# Altium schematic sheet StudentForce_MCU.SchDoc
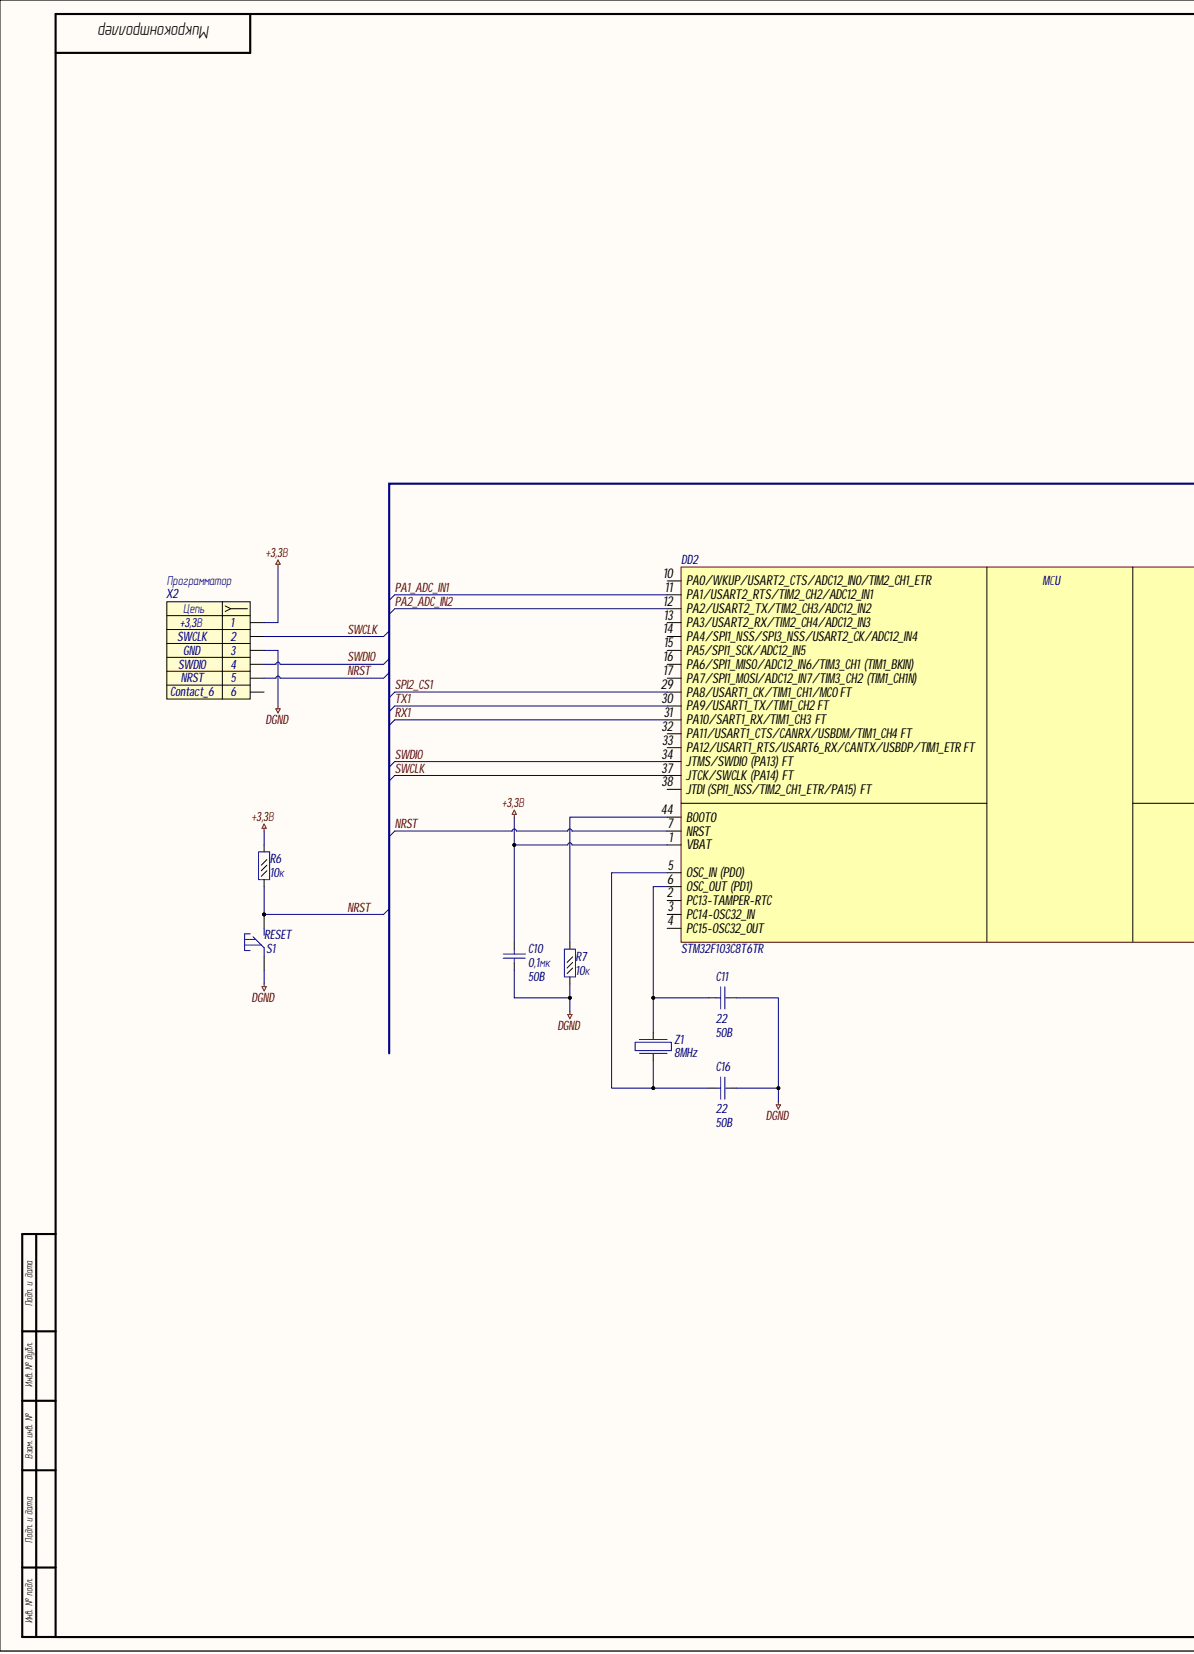
[diagram p0: StudentForce_MCU.SchDoc, left]
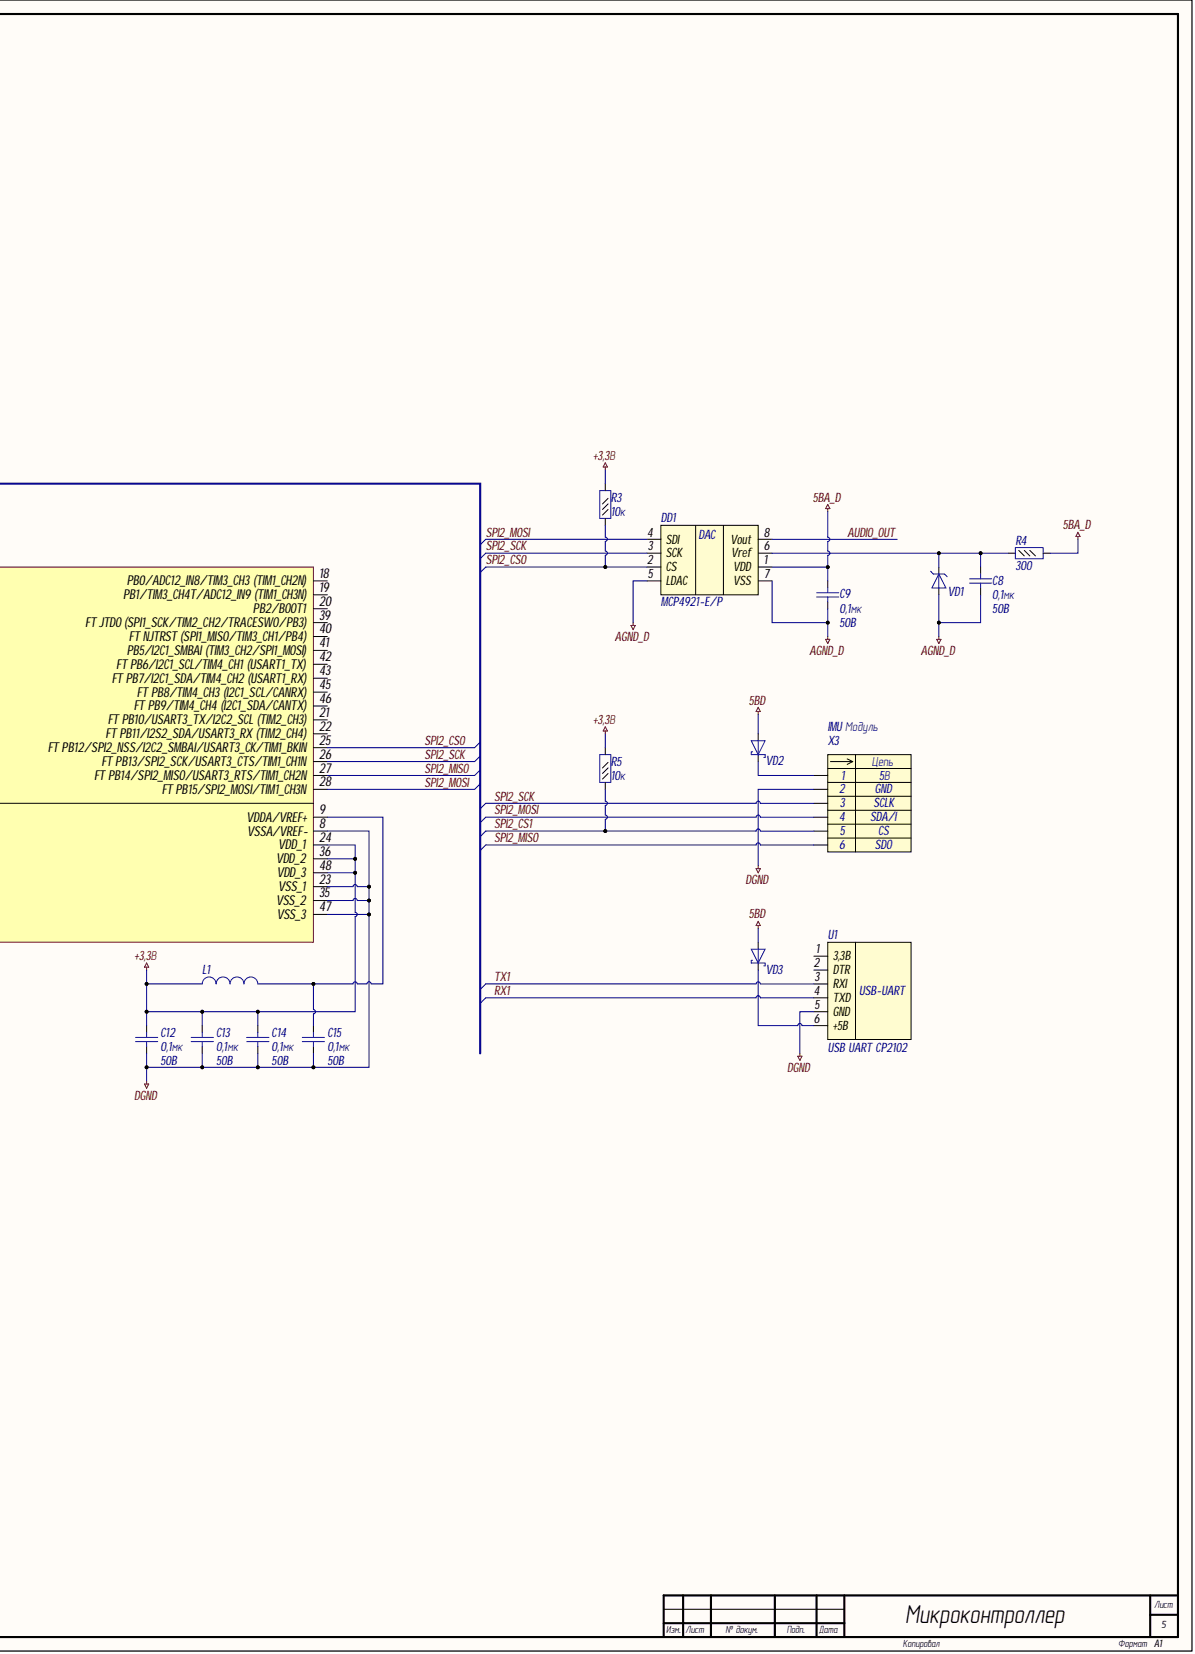
[diagram p1: StudentForce_MCU.SchDoc, right]

## Parts
C8  value 0,1мк  Voltage: 50B  [C0603]  (hidden comment: Capacitor)
C9  value 0,1мк  Voltage: 50B  [C0603]  (hidden comment: Capacitor)
C10  value 0,1мк  Voltage: 50B  [C0603]  (hidden comment: Capacitor)
C11  value 22  Voltage: 50B  [C0603]  (hidden comment: Capacitor)
C12  value 0,1мк  Voltage: 50B  [C0603]  (hidden comment: Capacitor)
C13  value 0,1мк  Voltage: 50B  [C0603]  (hidden comment: Capacitor)
C14  value 0,1мк  Voltage: 50B  [C0603]  (hidden comment: Capacitor)
C15  value 0,1мк  Voltage: 50B  [C0603]  (hidden comment: Capacitor)
C16  value 22  Voltage: 50B  [C0603]  (hidden comment: Capacitor)
DD1  MCP4921-E/P  [SO8]
DD2  STM32F103C8T6TR  [LQFP48]
L1  Inductor  [L0603]  (value not drawn)
R3  value 10к  [R0603]  (hidden comment: Resistor_0,062Вт)
R4  value 300  [R0603]  (hidden comment: Resistor_0,062Вт)
R5  value 10к  [R0603]  (hidden comment: Resistor_0,062Вт)
R6  value 10к  [R0603]  (hidden comment: Resistor_0,062Вт)
R7  value 10к  [R0603]  (hidden comment: Resistor_0,062Вт)
S1  RESET  [Button_SMD]
U1  USB UART  [USB UART CP2102]
VD1  LM4040BIM3-2.5/NOPB  [SOT23-3]  (value not drawn)
VD2  DiodeSchottky  [SOD-523]  (value not drawn)
VD3  DiodeSchottky  [SOD-523]  (value not drawn)
X2  Программатор  Contact_1: +3,3В  Contact_2: SWCLK  Contact_3: GND  Contact_4: SWDIO  Contact_5: NRST  [PLS2-6]
X3  IMU Модуль  Contact_1: 5В  Contact_2: GND  Contact_3: SCLK  Contact_4: SDA/I  Contact_5: CS  Contact_6: SDO  [PLS-6]
Z1  Frequence: 8MHz  [HC-49S]  (hidden comment: Crystal)

## Nets
5BA_D: C9.2 DD1.1(VDD) R4.2
5BD: VD2.2 VD3.2
+3,3В: C10.2 C12.2 C13.2 C14.2 DD2.1(VBAT) DD2.24(VDD_1) DD2.36(VDD_2) DD2.48(VDD_3) L1.1 R3.2 R5.2 R6.2 X2.1
AGND_D: C8.1 C9.1 DD1.5(LDAC) DD1.7(VSS) VD1.2
DGND: C10.1 C11.2 C12.1 C13.1 C14.1 C15.1 C16.2 DD2.8(VSSA/VREF-) DD2.23(VSS_1) DD2.35(VSS_2) DD2.47(VSS_3) R7.1 S1.1 U1.5(GND) X2.3 X3.2
AUDIO_OUT: DD1.8(Vout)
NRST: DD2.7(NRST) R6.1 S1.4 X2.5
PA1_ADC_IN1: DD2.11(PA1/USART2_RTS/TIM2_CH2/ADC12_IN1)
PA2_ADC_IN2: DD2.12(PA2/USART2_TX/TIM2_CH3/ADC12_IN2)
RX1: DD2.31(PA10/SART1_RX/TIM1_CH3_FT) U1.4(TXD)
SPI2_CS0: DD1.2(CS) DD2.25(FT_PB12/SPI2_NSS/I2C2_SMBAI/USART3_CK/TIM1_BKIN) R3.1
SPI2_CS1: DD2.29(PA8/USART1_CK/TIM1_CH1/MCO_FT) R5.1 X3.5
SPI2_MISO: DD2.27(FT_PB14/SPI2_MISO/USART3_RTS/TIM1_CH2N) X3.6
SPI2_MOSI: DD1.4(SDI) DD2.28(FT_PB15/SPI2_MOSI/TIM1_CH3N) X3.4
SPI2_SCK: DD1.3(SCK) DD2.26(FT_PB13/SPI2_SCK/USART3_CTS/TIM1_CH1N) X3.3
SWCLK: DD2.37(JTCK/SWCLK_(PA14)_FT) X2.2
SWDIO: DD2.34(JTMS/SWDIO_(PA13)_FT) X2.4
TX1: DD2.30(PA9/USART1_TX/TIM1_CH2_FT) U1.3(RXI)
NetC8_2: C8.2 DD1.6(Vref) R4.1 VD1.1
NetC11_1: C11.1 DD2.6(OSC_OUT_(PD1)) Z1.2
NetC15_2: C15.2 DD2.9(VDDA/VREF+) L1.2
NetC16_1: C16.1 DD2.5(OSC_IN_(PDO)) Z1.1
NetDD2_44: DD2.44(BOOT0) R7.2
NetU1_6: U1.6(+5B) VD3.1
NetVD2_1: VD2.1 X3.1

Not connected: DD2 2(PC13-TAMPER-RTC) 3(PC14-OSC32_IN) 4(PC15-OSC32_OUT) 10(PA0/WKUP/USART2_CTS/ADC12_IN0/TIM2_CH1_ETR) 13(PA3/USART2_RX/TIM2_CH4/ADC12_IN3) 14(PA4/SPI1_NSS/SPI3_NSS/USART2_CK/ADC12_IN4) 15(PA5/SPI1_SCK/ADC12_IN5) 16(PA6/SPI1_MISO/ADC12_IN6/TIM3_CH1_(TIM1_BKIN)) 17(PA7/SPI1_MOSI/ADC12_IN7/TIM3_CH2_(TIM1_CH1N)) 18(PB0/ADC12_IN8/TIM3_CH3_(TIM1_CH2N)) 19(PB1/TIM3_CH4T/ADC12_IN9_(TIM1_CH3N)) 20(PB2/BOOT1) 21(FT_PB10/USART3_TX/I2C2_SCL_(TIM2_CH3)) 22(FT_PB11/I2S2_SDA/USART3_RX_(TIM2_CH4)) 32(PA11/USART1_CTS/CANRX/USBDM/TIM1_CH4_FT) 33(PA12/USART1_RTS/USART6_RX/CANTX/USBDP/TIM1_ETR_FT) 38(JTDI_(SPI1_NSS/TIM2_CH1_ETR/PA15)_FT) 39(FT_JTDO_(SPI1_SCK/TIM2_CH2/TRACESWO/PB3)) 40(FT_NJTRST_(SPI1_MISO/TIM3_CH1/PB4)) 41(PB5/I2C1_SMBAI_(TIM3_CH2/SPI1_MOSI)) 42(FT_PB6/I2C1_SCL/TIM4_CH1_(USART1_TX)) 43(FT_PB7/I2C1_SDA/TIM4_CH2_(USART1_RX)) 45(FT_PB8/TIM4_CH3_(I2C1_SCL/CANRX)) 46(FT_PB9/TIM4_CH4_(I2C1_SDA/CANTX)); U1 1(3,3B) 2(DTR); X2 6

## Library data of the parts
C8-C10 C12-C15 = cap131: Конденсатор; Manufacture: Murata; Part Name: Ceramic; Part Number: GRM188R71H104KA93D; Database Table Name: Capacitors$; TKE: X7R; Component Kind: Standard; id: cap131; Library Name: MOP44.DbLib
C11 C16 = cap77: Конденсатор; Manufacture: Yageo; Part Name: Ceramic; Part Number: CC0603JRNPO9BN220; Database Table Name: Capacitors$; TKE: NP0; Component Kind: Standard; id: cap77; Library Name: MOP44.DbLib
DD1 = interf10: 12-Bit DAC with SPI™ Interface; Manufacture: Microchip; Part Name: DAC - SPI Rail-to-Rail; Database Table Name: Interface$; Number of Channel: 2; Power Supply: 2.7-5.5V; Resolution: 12bit; Type Supply: Single Polar; Vref output: yes; Component Kind: Standard; id: interf10; Library Name: MOP44.DbLib; Part Number: MCP4921-E/P
DD2 = microcont0: Medium-density performance line ARM®-based 32-bit MCU with 64  or 128 KB Flash, USB, CAN, 7 timers, 2 ADCs, 9 com. interfaces; Part Name: Microcontroller; ADC: 10x12b; Database Table Name: Microcontroller$; Flash: 64kb; Frequency: 72MHz; Interface: can, i2c, irda, lin, spi, uart, usb; Pin in: 37; Power Supply: 2.0-3.6 V; Component Kind: Standard; id: microcont0; Library Name: MOP44.DbLib; Part Number: STM32F103C8T6TR
L1 = ind0: EMI Suppression Filter; Part Name: EMI Suppression Filters; Part Number: BLM18PG181SN1D; Database Table Name: Inductor$; Rated Current: 1,5A; Resistance: 0,09Ohm; Component Kind: Standard; id: ind0; Library Name: MOP44.DbLib
R3 R5-R7 = res483: Резистор; Manufacture: Yageo; Part Number: RC0603FR-0710KL; Accuracy: 0.01; Database Table Name: Resistor$; Component Kind: Standard; id: res483; Library Name: MOP44.DbLib; Part Name: Resistor; Power: 0,062Вт
R4 = res446: Резистор; Manufacture: Yageo; Part Number: RC0603FR-07300RL; Accuracy: 0.01; Database Table Name: Resistor$; Component Kind: Standard; id: res446; Library Name: MOP44.DbLib; Part Name: Resistor; Power: 0,062Вт
S1 = btn4: Кнопка; Part Name: Button 5mm; Database Table Name: Button$; Component Kind: Standard; id: btn4; Library Name: MOP44.DbLib
U1 = module2: USB UART module cp2102; Database Table Name: Module$; Component Kind: Standard; id: module2; Library Name: MOP44.DbLib; Part Name: USB UART CP2102
VD1 = LM4040BIM3-2.5/NOPB: Прецезионный источник опорного напряжения; Part Name: Precision Micropower Shunt Voltage Reference; Accuracy: 0.002; Database Table Name: VoltageReference$; id: ref0; Output current: 15mA; Voltage min stabilize: 2,5B; Component Kind: Standard; Library Name: MOP44.DbLib; Part Number: LM4040BIM3-2.5/NOPB
VD2 VD3 = diode12: Диод Шоттки; Manufacture: NXP Semiconductor; Part Number: PMEG2010AEB.115; Breakdown Current: 600uA; Capacitance: 25pF; Database Table Name: Diode$; Forward Current: 1А; Forward Voltage: 0,62B; Max Reverse Voltage: 20B; Number of Channels: 1; Component Kind: Standard; id: diode12; Library Name: MOP44.DbLib; Part Name: Diode Schottky
X2 = connect0: Разъем - 6 конт. розетка; Part Name: MKII; Database Table Name: Connector$; Component Kind: Standard; Contact_6: Contact_6; id: connect0; Library Name: MOP44.DbLib
X3 = connect49: Разъем - 6 конт. вилка; Part Name: Inertial Measurement Unit (IMU); Database Table Name: Connector$; Component Kind: Standard; id: connect49; Library Name: MOP44.DbLib; Part Number: MPU-9250
Z1 = crystal3: Кварцевый резонатор; Part Number: 8.000MHzHC-49US; Database Table Name: Crystal$; Component Kind: Standard; id: crystal3; Library Name: MOP44.DbLib
